# Altium schematic sheet TFT LCD ER-TFT018-2.SchDoc("TFT LCD ER-TFT018-2")
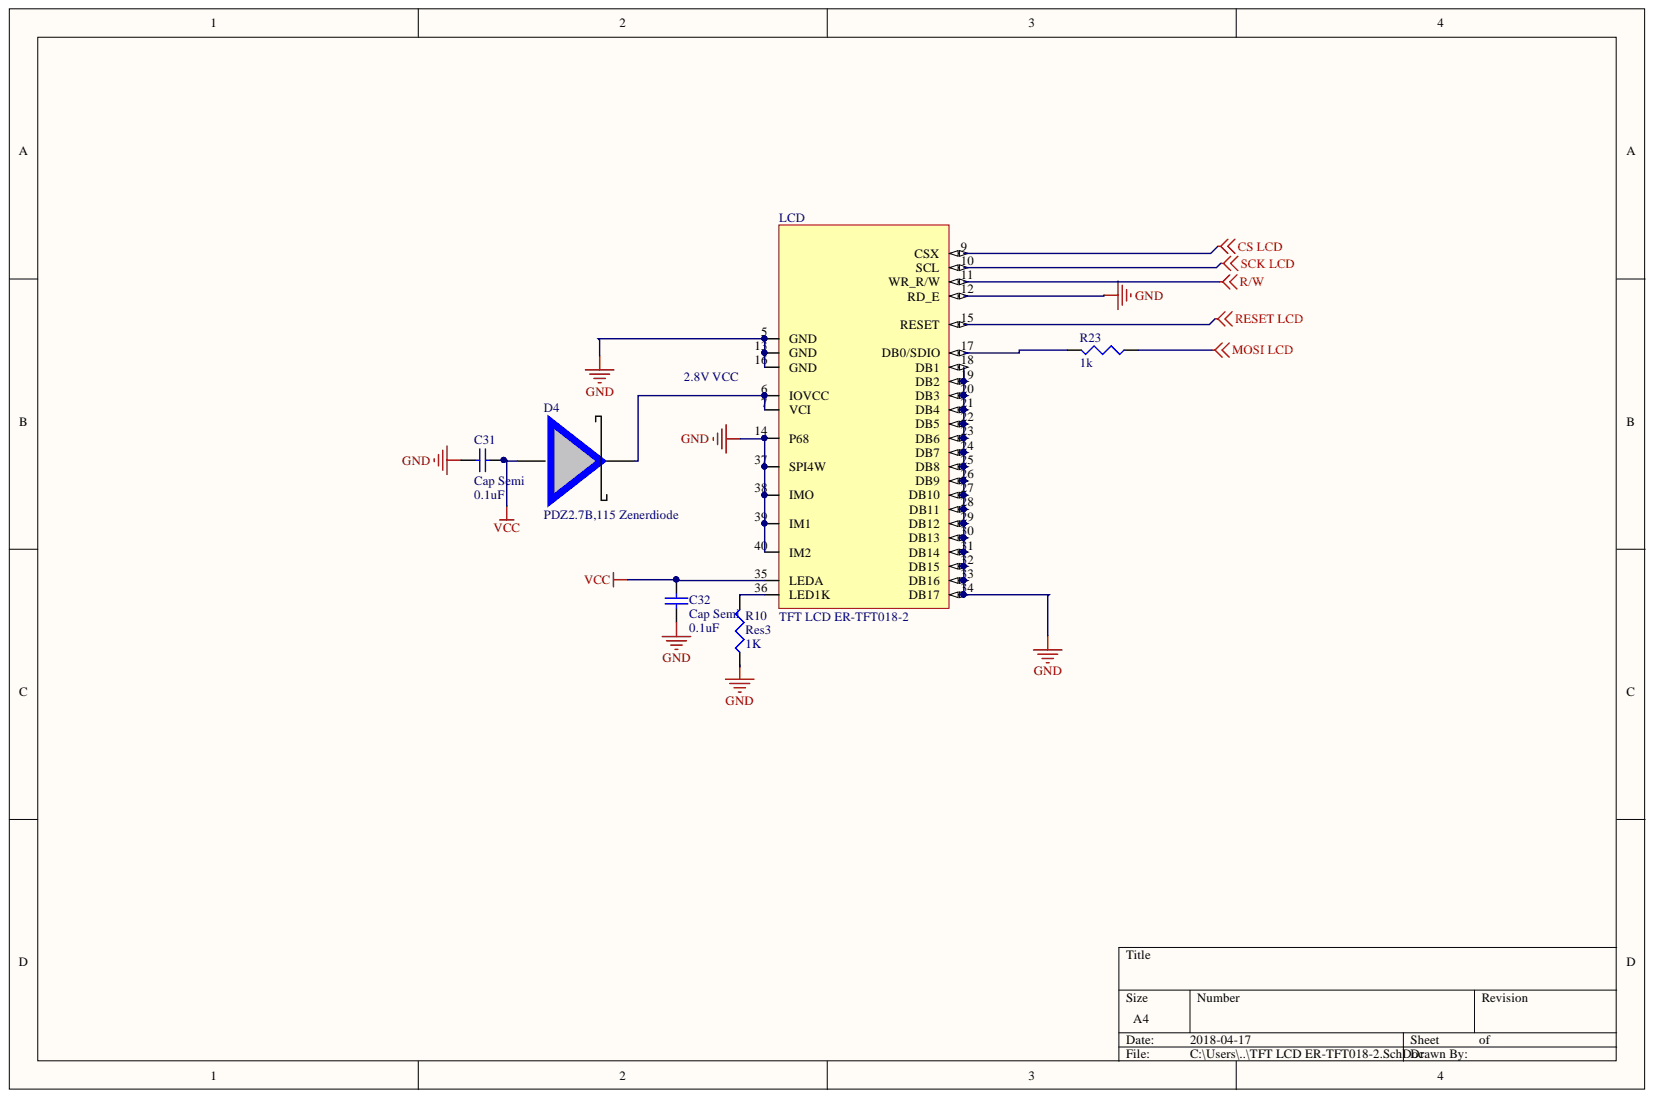
[diagram p0: TFT LCD ER-TFT018-2.SchDoc]

## Parts
C31  Cap Semi  value 0.1uF  [1608[0603]]
C32  Cap Semi  [1608[0603]]  (value not drawn)
D4  PDZ2.7B,115 Zenerdiode  [PDZ2.7B,115]
LCD  TFT LCD ER-TFT018-2  [LCD TFT Display]
R10  Res3  [J1-0603]  (value not drawn)
R23  Res3  [J1-0603]  (value not drawn)

## Nets
CS LCD: LCD.9
GND: C31.1 C32.1 LCD.5 LCD.12 LCD.13 LCD.14 LCD.16 LCD.18 LCD.19 LCD.20 LCD.21 LCD.22 LCD.23 LCD.24 LCD.25 LCD.26 LCD.27 LCD.28 LCD.29 LCD.30 LCD.31 LCD.32 LCD.33 LCD.34 LCD.37 LCD.38 LCD.39 LCD.40 R10.1
MOSI LCD: R23.1
R/W: LCD.11
RESET LCD: LCD.15
SCK LCD: LCD.10
VCC: C31.2 C32.2 D4.2 LCD.35
NetD4_1: D4.1 LCD.6 LCD.7
NetLCD_17: LCD.17 R23.2
NetLCD_36: LCD.36 R10.2

## Library data of the parts
C31 C32 = Cap Semi: Capacitor (Semiconductor SIM Model); Value: 0.1uF; Simulation: CAP; Signal Integrity: Cap Semi; Published: 8-Jun-2000; PackageReference: C1206; PackageDescription: Chip Capacitor; Library Name: Miscellaneous Devices.IntLib; LatestRevisionNote: IPC-7351 Footprint Added.; LatestRevisionDate: 29-May-2009; Component Kind: Standard; Publisher: Altium Limited
R10 = Res3: Resistor; Value: 1K; Simulation: RESISTOR; Signal Integrity: Res; Published: 8-Jun-2000; PackageReference: J1-0603; PackageDescription: Chip Resistor; Library Name: Miscellaneous Devices.IntLib; LatestRevisionNote: IPC-7351 Footprint Added.; LatestRevisionDate: 29-May-2009; Component Kind: Standard; Publisher: Altium Limited
R23 = Res3: Resistor; Value: 1k; Simulation: RESISTOR; Signal Integrity: Res; Published: 8-Jun-2000; PackageReference: J1-0603; PackageDescription: Chip Resistor; Library Name: Miscellaneous Devices.IntLib; LatestRevisionNote: IPC-7351 Footprint Added.; LatestRevisionDate: 29-May-2009; Component Kind: Standard; Publisher: Altium Limited
D4 = PDZ2.7B,115 Zenerdiode: Component Kind: Standard; Library Name: HGCDLibrary2.IntLib
LCD = TFT LCD ER-TFT018-2: Component Kind: Standard; Library Name: HGCDLibrary.IntLib
